# Altium schematic sheet 74hc595.SchDoc(74hc595)
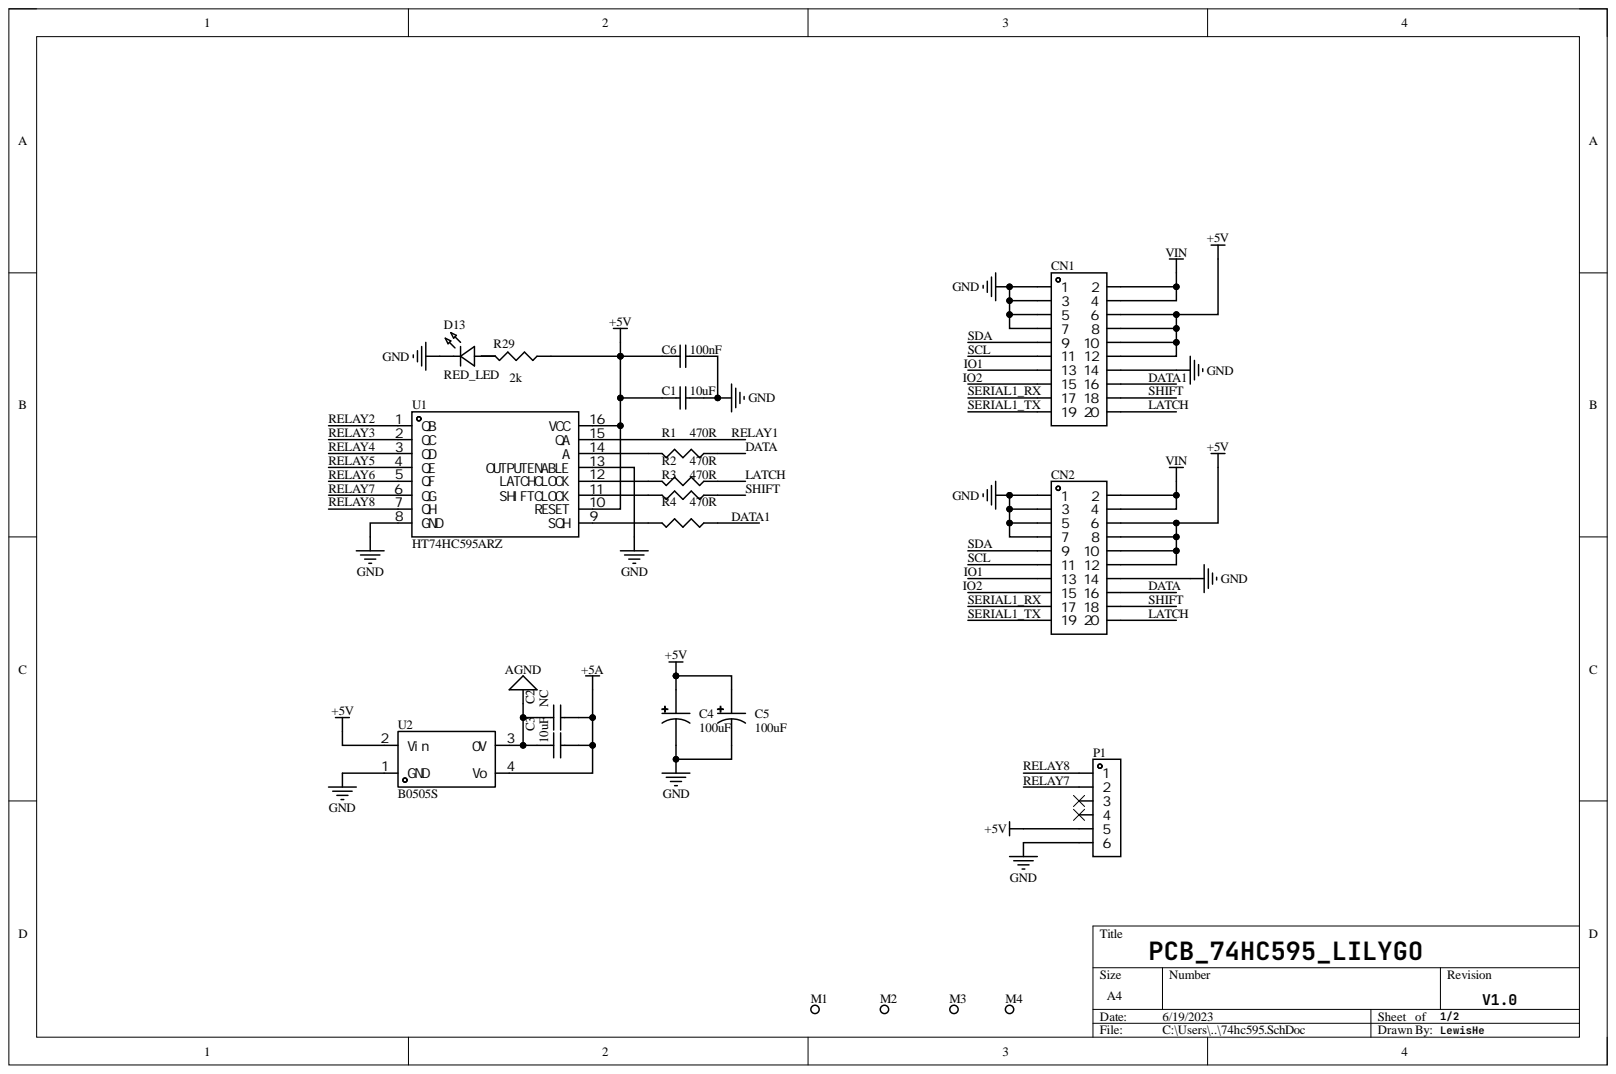
[diagram p0: 74hc595.SchDoc]

## Parts
C1  10uF  [C0603]
C2  NC  [C1206]
C3  10uF  [C1206]
C4  100uF  [CAP-SMD_BD6.3-L6.6-W6.6-LS7.6-FD]
C5  100uF  [CAP-SMD_BD6.3-L6.6-W6.6-LS7.6-FD]
C6  100nF  [C0603]
CN1  321020SG0ABK00A01  [IDC-TH_20P-P2.54-V-R2-C10-S2.54]  (value not drawn)
CN2  321020SG0ABK00A01  [IDC-TH_20P-P2.54-V-R2-C10-S2.54]  (value not drawn)
D13  RED_LED  [LED0603_RED]
M1  M3螺丝孔  [M3螺丝孔]  (value not drawn)
M2  M3螺丝孔  [M3螺丝孔]  (value not drawn)
M3  M3螺丝孔  [M3螺丝孔]  (value not drawn)
M4  M3螺丝孔  [M3螺丝孔]  (value not drawn)
P1  PZ254V-11-06P  [HDR-TH_6P-P2.54-V-M]  (value not drawn)
R1  470R  [R0402]
R2  470R  [R0402]
R3  470R  [R0402]
R4  470R  [R0402]
R29  2k  [R0603]
U1  HT74HC595ARZ  [SOIC-16_L9.9-W3.9-P1.27-LS6.0-BL]
U2  B0505S  [PWRM-TH_BXXXXS-1W]

## Nets
+5A: C2.2 C3.2 U2.4(Vo)
+5V: C1.1 C4.1 C5.1 C6.1 CN1.6 CN1.8 CN1.10 CN1.12 CN2.6 CN2.8 CN2.10 CN2.12 P1.5 R29.1 U1.10(RESET) U1.16(VCC) U2.2(Vin)
AGND: C2.1 C3.1 U2.3(0V)
GND: C1.2 C4.2 C5.2 C6.2 CN1.1 CN1.3 CN1.5 CN1.7 CN1.14 CN2.1 CN2.3 CN2.5 CN2.7 CN2.14 D13.2 P1.6 U1.8(GND) U1.13(OUTPUTENABLE) U2.1(GND)
VIN: CN1.2 CN1.4 CN2.2 CN2.4
DATA: CN2.16 R1.1
DATA1: CN1.16 R4.1
IO1: CN1.13 CN2.13
IO2: CN1.15 CN2.15
LATCH: CN1.20 CN2.20 R2.1
RELAY1: U1.15(QA)
RELAY2: U1.1(QB)
RELAY3: U1.2(QC)
RELAY4: U1.3(QD)
RELAY5: U1.4(QE)
RELAY6: U1.5(QF)
RELAY7: P1.2 U1.6(QG)
RELAY8: P1.1 U1.7(QH)
SCL: CN1.11 CN2.11
SDA: CN1.9 CN2.9
SERIAL1_RX: CN1.17 CN2.17
SERIAL1_TX: CN1.19 CN2.19
SHIFT: CN1.18 CN2.18 R3.1
NetD13_1: D13.1 R29.2
NetR1_2: R1.2 U1.14(A)
NetR2_2: R2.2 U1.12(LATCHCLOCK)
NetR3_2: R3.2 U1.11(SHIFTCLOCK)
NetR4_2: R4.2 U1.9(SQH)

Not connected: P1 3 4

## Library data of the parts
C1 C6 = Cap: Capacitor; Component Kind: Standard; LatestRevisionDate: 17-Jul-2002; LatestRevisionNote: Re-released for DXP Platform.; Library Name: Miscellaneous Devices.IntLib; PackageDescription: Radial Cap, Thru-Hole; 2 Leads; 0.3 in Pin Spacing; PackageReference: RAD-0.3; Published: 8-Jun-2000; Signal Integrity: Cap; Simulation: CAP; Publisher: Altium Limited
  C1: Value: 10uF
  C6: Value: 100nF
C2 C3 = CAP NP_9: Manufacture: WALSIN; Manufacturer: VISHAY; Manufacturer #1???: FengHua(风华); Manufacturer_ID: 1,004; Part Number: 0603F104Z250CT; AML P/N: 123-12Z4-1040C; Description #1: 介质材料[COG（NPO）/COH]通用型属I类电容，容量稳定，不随温度、时间和电压变化而变化，适用高频电路。; Description #2: X7R贴片电容属EIA规定的Class 2类材料电容，容量随温度、时间、电压变化而变化。; Descriptions: CAP CERAMIC .1uF -20%/+80% 25V 0603 SMD RoHS walsin; Dielectric: X7R; DNS: 0; Medium material: COG(NP0)/COH/X7R; PCB Footprint: C0805; Rating Voltage: 50(A)Vdc; Tolerance: ±10%; Voltage Rating: 25V; Component Kind: Standard; Datasheet: \\File1-shanghai\sha\Specifications\Datasheets_IC\_Manufacturer\Walsin\Capacitor\MLCC catalogue_200510.pdf; Manufacturer#2: Vishay（威世）; Manufacturer#3: AVX; Part Type: SMD Capacitance（贴片电容）; VoltageRating: 25V
CN1 CN2 = 321020SG0ABK00A01: 连接器类型:简牛座;间距:2.54mm;每排PIN数:10;排数:2;行距:2.54mm;封装形式:直插;触头材质:黄铜;触头镀层:金;; Component Kind: Standard; Library Name: 常用.SCHLIB
D13 = LED0603: 红灯; Component Kind: Standard; Library Name: 常用.SCHLIB
P1 = PZ254V-11-06P: 排数:单排;总PIN位数:6;间距:2.54mm;插针结构:1x6P;行距:-;安装类型:直插;圆针/方针:方针;额定电流:-;额定电压:-;配合针长度:6mm;塑高:2.5mm;端接针长度:3mm;触头材质:黄铜;触头镀层:-;工作温度范围:-40℃~+105℃;颜色:黑色;; Component Kind: Standard; Library Name: 常用.SCHLIB
R1-R4 R29 = Res3: Resistor; Component Kind: Standard; LatestRevisionDate: 29-May-2009; LatestRevisionNote: IPC-7351 Footprint Added.; Library Name: Miscellaneous Devices.IntLib; PackageDescription: Chip Resistor; PackageReference: J1-0603; Published: 8-Jun-2000; Signal Integrity: Res; Simulation: RESISTOR; Publisher: Altium Limited
  R1-R4: Value: 470R
  R29: Value: 2k
U1 = HT74HC595ARZ: 8位串行输入，串行或并行输出移位寄存器与输出锁存器; Component Kind: Standard; Library Name: 常用.SCHLIB
U2 = B0505S-1W: 转换类型:DC-DC;输出路数:1;输入电压(DC):4.5V~5.5V;输出电压:5V;输出电流(最大值):200mA;输出功率:1W;转换效率:80%;; Component Kind: Standard; Library Name: 常用.SCHLIB
C4 C5 = 100uF: Component Kind: Standard; Library Name: 常用.SCHLIB
M1-M4 = M3螺丝孔: Component Kind: Standard; Description: M3螺丝孔; Library Name: 常用.SCHLIB
